# Altium schematic sheet PPS.SchDoc
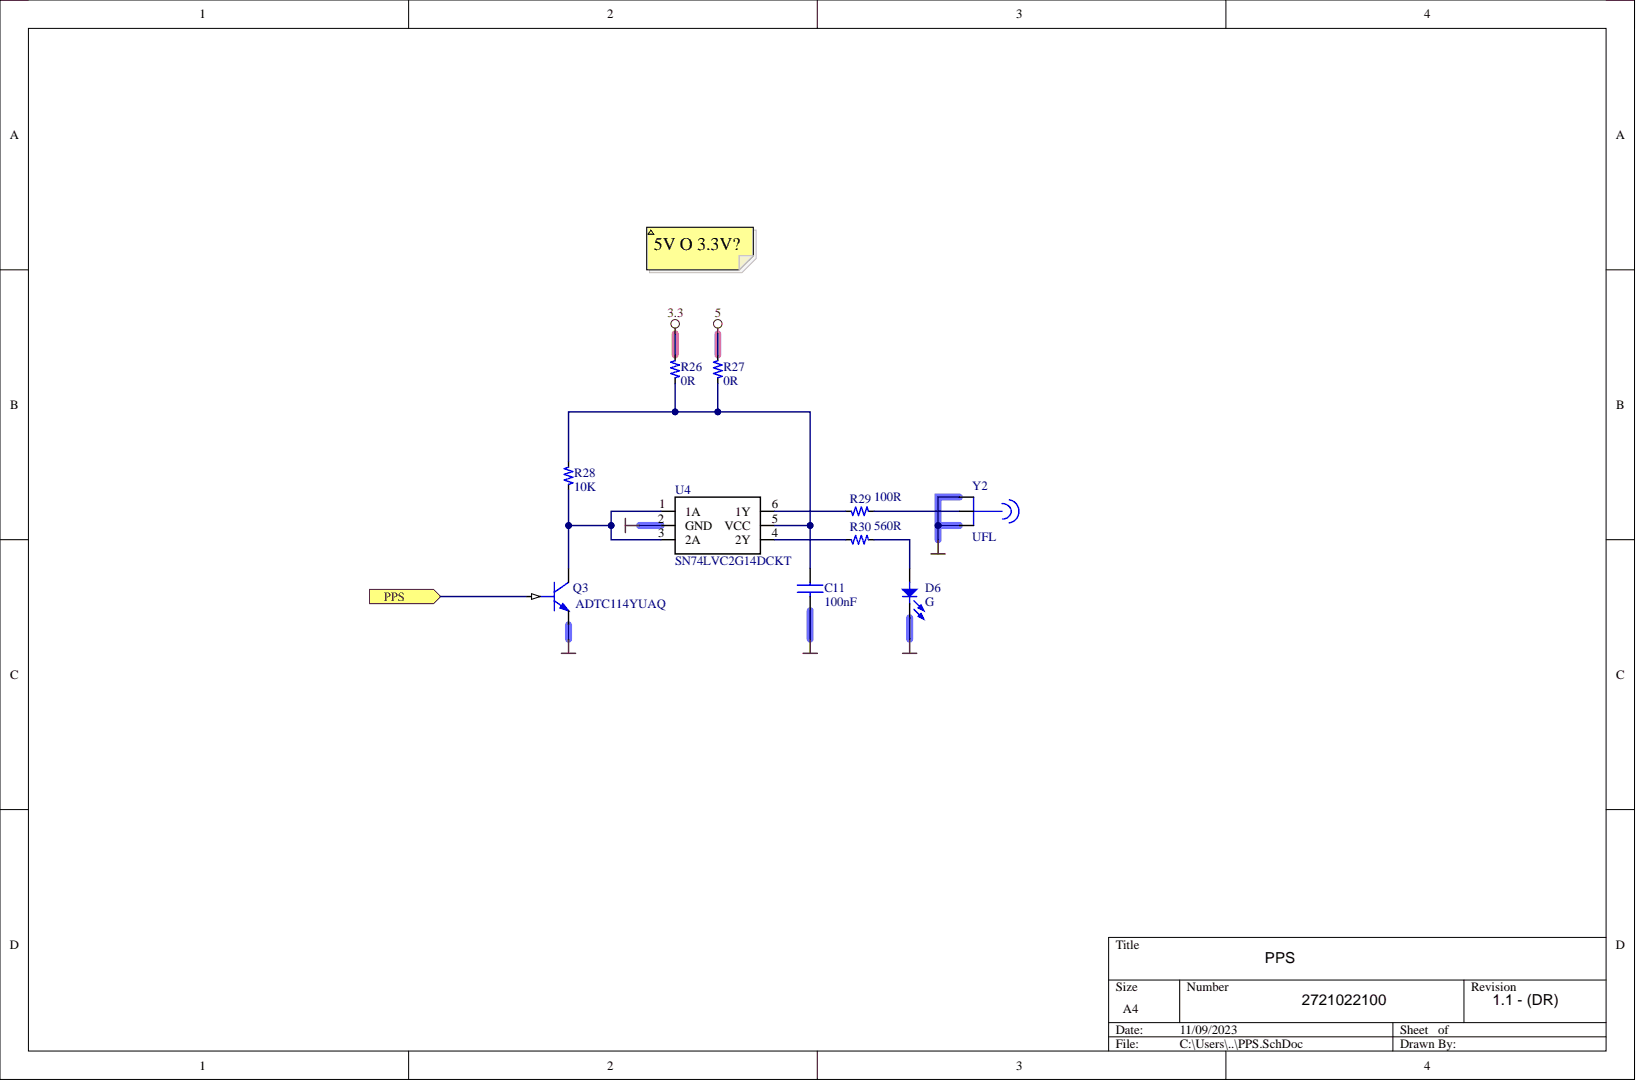
[diagram p0: PPS.SchDoc]

## Parts
C11  100nF  [RC0402_1]
D6  G  [LED_SMD_0603_GREEN]
Q3  ADTC114YUAQ  [SOT323]
R26  0R  [RC0603_1]
R27  0R  [RC0603_1]
R28  10K  [RC0603_1]
R29  100R  [RC0603_1]
R30  560R  [RC0603_1]
U4  SN74LVC2G14DCKT  [SC70_6PIN_0.65P_1.25W_TEXAS]
Y2  UFL  [CON_SMD_UFLRSMT10_HIROSE]

## Ports
PPS

## Nets
3.3: R26.1
5: R27.1
GND: C11.2 D6.CATHODE Q3.EMITTER U4.2(GND) Y2.2 Y2.3
PPS: Q3.BASE ; port PPS
NetC11_1: C11.1 R26.2 R27.2 R28.1 U4.5(VCC)
NetD6_ANODE: D6.ANODE R30.2
NetQ3_COLLECTOR: Q3.COLLECTOR R28.2 U4.1(1A) U4.3(2A)
NetR29_1: R29.1 U4.6(1Y)
NetR29_2: R29.2 Y2.1
NetR30_1: R30.1 U4.4(2Y)

## Library data of the parts
C11 = GRM155R71C104KA88D: CAP, CERAMICA, 100NF, 16V, X7R, 0402; Manufacturer: MURATA; Order c: 8819742; upBCE: 0.01; Value: 0.1UF; Case: 0402; Component Kind: Standard; Library Name: mylibA.SchLib; Manufacturer n: GRM155R71C104KA88D
D6 = LED_ GREEN_0603_WURTH: LED, 0603, GREEN, 15MCD, 675NM; Manufacturer: Wurth; Manufacturer n: 150060VS55040; upBCE: 0.1; Case: SMD; Component Kind: Standard; Library Name: mylibA.SchLib; Value: GREEN
Q3 = TRANSISTOR_ADTC114YUAQ: DIGITAL TRANSISTOR, 10K-47K, NPN, 50V, SC-75-3; Manufacturer: DIODES; Manufacturer n: ADTC114YUAQ-7; Order c: 621-ADTC114YUAQ-7; upBCE: 0.042; Component Kind: Standard; Library Name: mylibA.SchLib
R26 R27 = CRCW06030000Z0EA: RESISTORE, 0R, 0.1W, 1%, 0603, SMD; Manufacturer: Vishay Dralogic; Order c: 1469739; upBCE: 0.00066; Case: 0603; Component Kind: Standard; Library Name: mylibA.SchLib; Manufacturer n: CRCW06030000Z0EA; Value: 0R
R28 = CRCW060310K0FKEA: RESISTORE, 10K, 0.1W, 1%, 0603, SMD; Manufacturer: Vishay Dralogic; Order c: 1469748; upBCE: 0.00066; Case: 0603; Component Kind: Standard; Library Name: mylibA.SchLib; Manufacturer n: CRCW060310K0FKEA; Value: 10K
R29 = CRCW0603100RFKEA: RESISTORE, 100R, 0.1W, 1%, 0603, SMD; Manufacturer: Vishay Dralogic; Order c: 1469752; upBCE: 0.00066; Case: 0603; Component Kind: Standard; Library Name: mylibA.SchLib; Manufacturer n: CRCW0603100RFKEA; Value: 100R
R30 = CRCW0603560RFKEA: RESISTORE, 560R, 0.1W, 1%, 0603, SMD; Manufacturer: Vishay Dralogic; Order c: 71-CRCW0603-560-E3; upBCE: 0.00066; Case: 0603; Component Kind: Standard; Library Name: mylibA.SchLib; Manufacturer n: CRCW0603560RFKEA; Value: 560R
U4 = SN74LVC2G14DCKT: Dual Schmitt Trigger Inverter, SC70-6; Manufacturer: TI; Order c: 595-SN74LVC2G14DCKT; upBCE: 0.65; Case: SC70-6; Component Kind: Standard; Library Name: mylibA.SchLib; Manufacturer n: SN74LVC2G14DCKT
Y2 = CONNECTOR_UFL_U.FL-R-SMT: U.FL; Manufacturer: HIROSE; Manufacturer n: U.FL-R-SMT(10); Order c: 798-U.FL-R-SMT10; upBCE: 0.59; Value: U.FL-R-SMT(10); Case: SMD; Component Kind: Standard; Library Name: mylibA.SchLib
